# Altium schematic sheet rpi_adapter_2x4L_sink.SchDoc
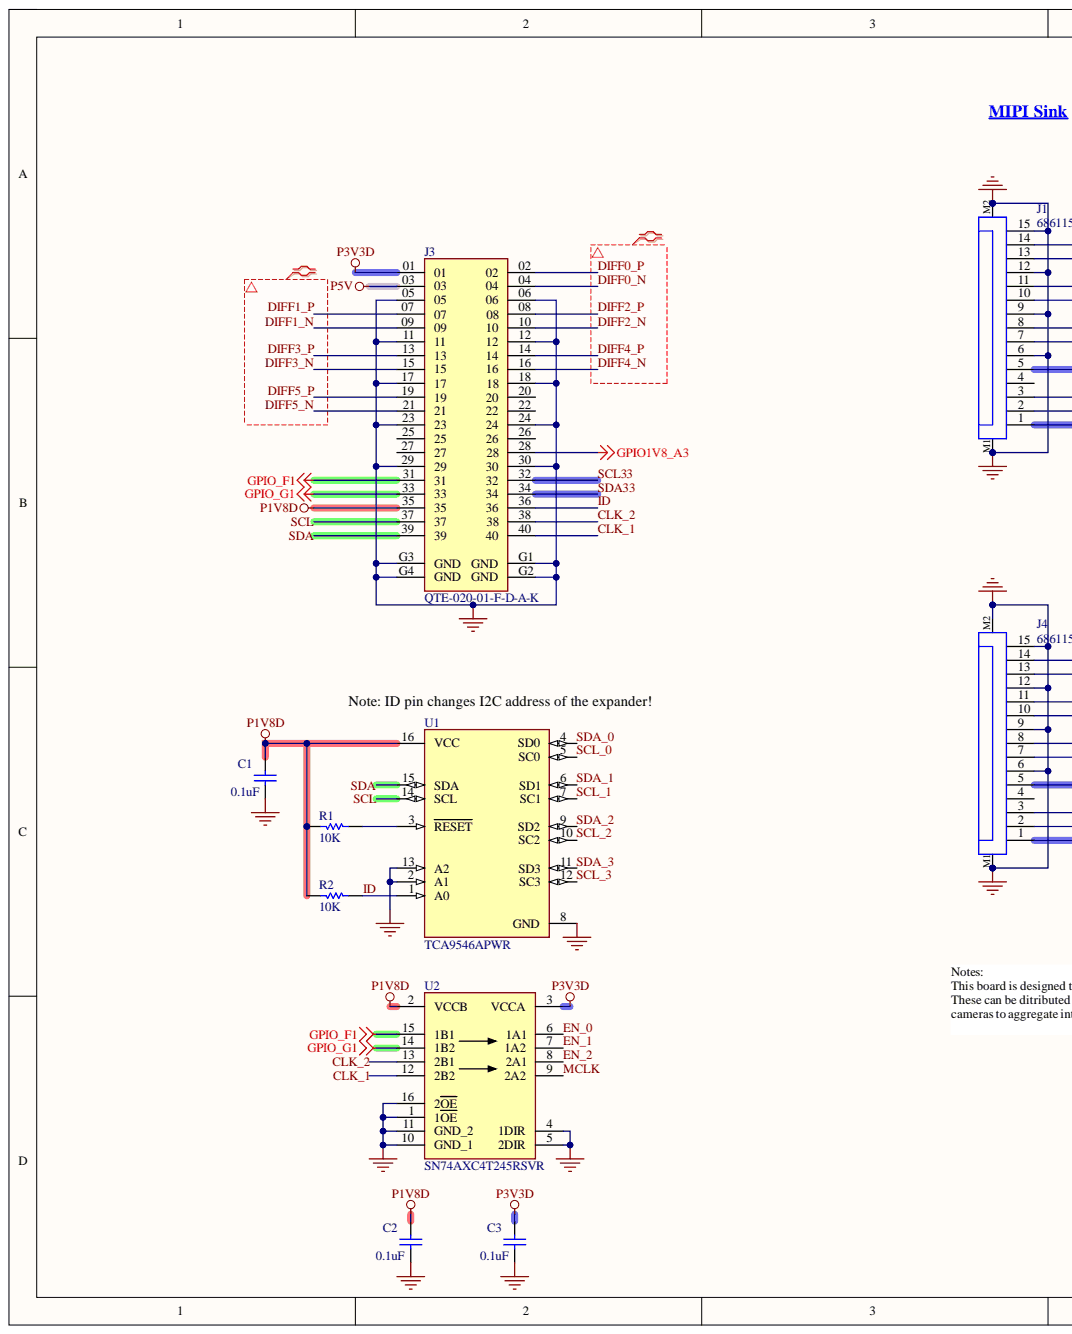
[diagram p0: rpi_adapter_2x4L_sink.SchDoc, left]
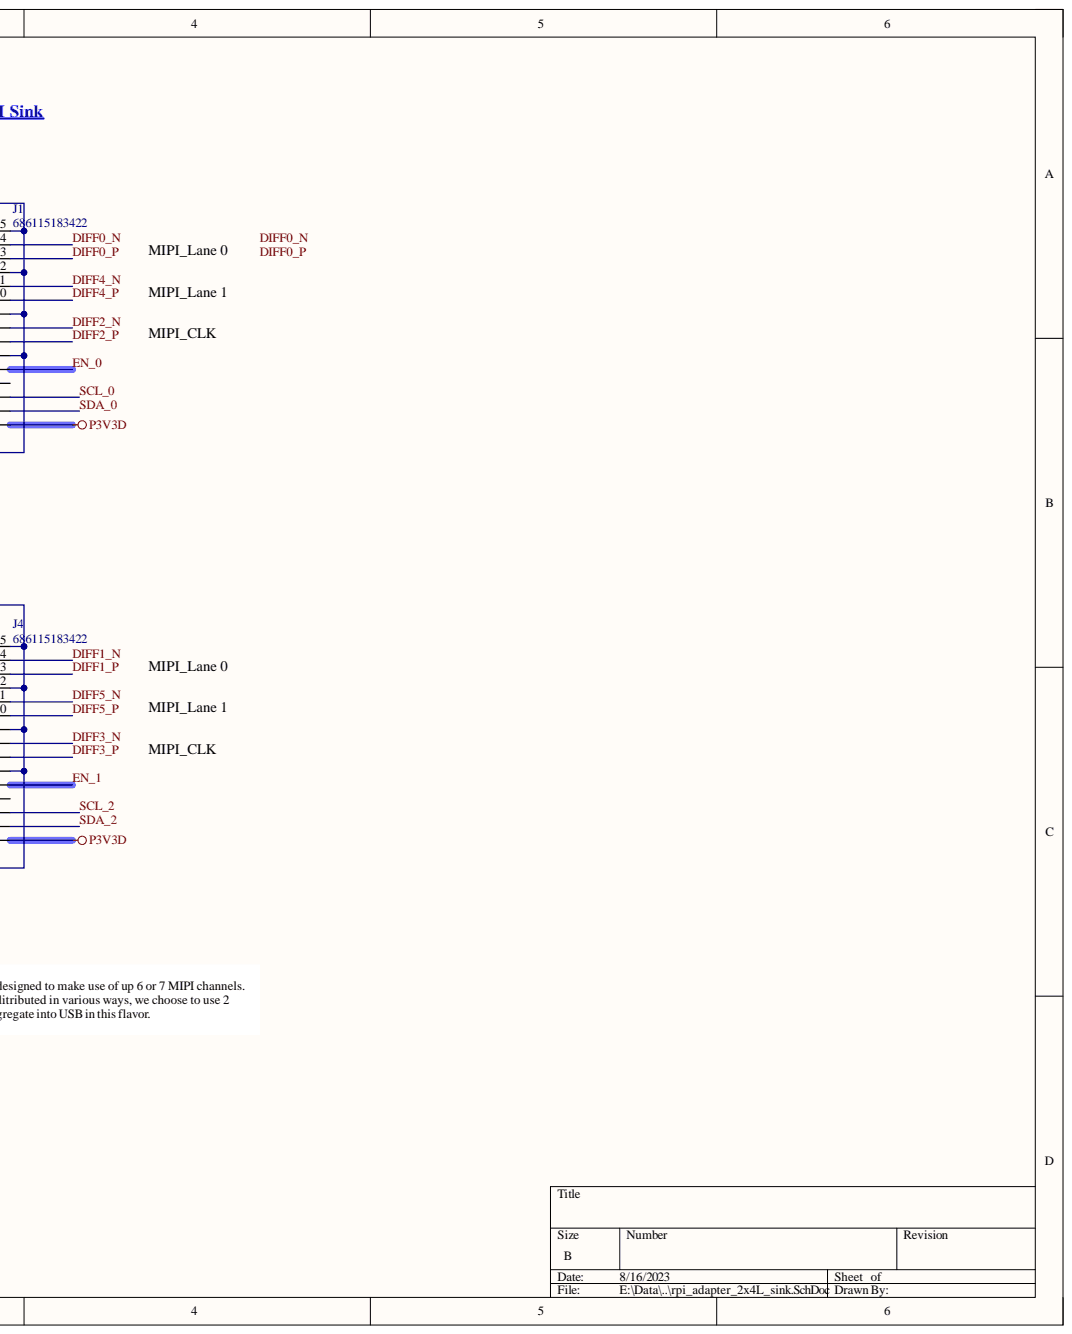
[diagram p1: rpi_adapter_2x4L_sink.SchDoc, right]

## Parts
C1  0.1uF  [CAPC1005X55USR]
C2  0.1uF  [CAPC1005X55USR]
C3  0.1uF  [CAPC1005X55USR]
J1  686115183422  [686115183422]
J3  QTE-020-01-F-D-A-K  [SAMTEC_QSE-020-01-XXX-D-A-L]
J4  686115183422  [686115183422]
R1  10K  [RESC1005X40USR]
R2  10K  [RESC1005X40USR]
U1  TCA9546APWR  [FP-PW0016A-MFG]
U2  SN74AXC4T245RSVR  [QFN40P260X180X55-16N]

## Nets
GND: C1.1 C2.1 C3.1 J1.6 J1.9 J1.12 J1.15 J1.M1 J1.M2 J3.05 J3.06 J3.11 J3.12 J3.17 J3.18 J3.23 J3.24 J3.29 J3.30 J3.G1(GND) J3.G2(GND) J3.G3(GND) J3.G4(GND) J4.6 J4.9 J4.12 J4.15 J4.M1 J4.M2 U1.2(A1) U1.8(GND) U1.13(A2) U2.1(1OE) U2.4(1DIR) U2.5(2DIR) U2.10(GND_1) U2.11(GND_2) U2.16(2OE)
GPIO1V8_A3: J3.28
GPIO_F1: J3.31 U2.15(1B1)
GPIO_G1: J3.33 U2.14(1B2)
P1V8D: C1.2 C2.2 J3.35 R1.1 R2.1 U1.16(VCC) U2.2(VCCB)
P3V3D: C3.2 J1.1 J3.01 J4.1 U2.3(VCCA)
P5V: J3.03
CLK_1: J3.40 U2.12(2B2)
CLK_2: J3.38 U2.13(2B1)
DIFF0_N: J1.14 J3.04
DIFF0_P: J1.13 J3.02
DIFF1_N: J3.09 J4.14
DIFF1_P: J3.07 J4.13
DIFF2_N: J1.8 J3.10
DIFF2_P: J1.7 J3.08
DIFF3_N: J3.15 J4.8
DIFF3_P: J3.13 J4.7
DIFF4_N: J1.11 J3.16
DIFF4_P: J1.10 J3.14
DIFF5_N: J3.21 J4.11
DIFF5_P: J3.19 J4.10
EN_0: J1.5 U2.6(1A1)
EN_1: J4.5 U2.7(1A2)
EN_2: U2.8(2A1)
ID: J3.36 R2.2 U1.1(A0)
MCLK: U2.9(2A2)
SCL: J3.37 U1.14(SCL)
SCL33: J3.32
SCL_0: J1.3 U1.5(SC0)
SCL_1: U1.7(SC1)
SCL_2: J4.3 U1.10(SC2)
SCL_3: U1.12(SC3)
SDA: J3.39 U1.15(SDA)
SDA33: J3.34
SDA_0: J1.2 U1.4(SD0)
SDA_1: U1.6(SD1)
SDA_2: J4.2 U1.9(SD2)
SDA_3: U1.11(SD3)
NetR1_2: R1.2 U1.3(RESET)

Not connected: J1 4; J3 20 22 25 26 27; J4 4

## Library data of the parts
C1-C3 = CAP CER 0.1UF 16V X7R 0402: Manufacturer: TDK Corporation; Manufacturer Part Number: CGA2B1X7R1C104K050BC; Component Kind: Standard; Description: CAP CER 0.1UF 16V X7R 0402; Height: 0.55mm; Library Name: NX Module baseboard.SCHLIB; Package: 0402; Supplier 1: Digi-Key; Supplier Part Number 1: 445-5613-2-ND; value: 0.1uF
J1 J4 = CMP-03913-81848314-1: 686115183422 WR-FPC 1.00mm SMT Horiz. Top Cont. Low Profile; Contact Resistance: 30mΩ; Current Rating: 800mA; Gender: Receptacle; Insulation Resistance: 100MΩ; Operating Supply Voltage: 50V; Pitch: 1mm; Component Kind: Standard; Lead Pitch: 1mm; Length: 16.1mm; Library Name: Altium Content Vault; Max Operating Temperature: 85°C; Min Operating Temperature: -25°C; NexarPartId: 81848314; Number of Pins: 15; Orientation: Horizontal; Packaging: Tape and Reel; RoHS: Compliant
J3 = QSE-020-01-F-D-A-K: Q Strip® High-Speed Ground Plane Header, 0.80mm Pitch; Manufacturer: Samtec; Gender: Male; Mated Stacking Heights: 5mm; Number of Contacts: 40; Pitch: 0.031inch; Category: Board to Board Connectors; Component Kind: Standard; ComponentLink1Description: https://datasheet.ciiva.com/6924/qte-xxx-xx-x-d-xxx-mkt-6924765.pdf?src-supplier=Digi-Key; ComponentLink1URL: https://datasheet.ciiva.com/6924/qte-xxx-xx-x-d-xxx-mkt-6924765.pdf?src-supplier=Digi-Key; Contact Plating: Gold; Library Name: NX Module baseboard.SchLib; Manufacturer Part Number: QTE-020-01-F-D-A; Mounting Technology: Surface Mount; Number of Positions: 40; Number of Rows: 2; Package Quantity: 1; Packaging: Tray; Pricing: 1=9.48000, 10=8.53000, 25=8.03560, 40=7.82950, 96=7.62344, 288=6.79931, 480=6.38725, 960=5.64550 (USD); RoHS: Yes; RoHS Compliant: Yes; Series: QStrip® QTE; Stock: 1329; Supplier 1: Digi-Key; Supplier Part Number 1: SAM8846-ND; Termination: Solder
R1 R2 = RES SMD 10K OHM 1% 1/16W 0402: Manufacturer: Samsung Electro-Mechanics; Manufacturer Part Number: RC1005F103CS; Component Kind: Standard; Description: RES SMD 10K OHM 1% 1/16W 0402; Height: 0.4mm; Library Name: NX Module baseboard.SCHLIB; Package: 0402; Supplier 1: Digi-Key; Supplier Part Number 1: 1276-3431-2-ND; value: 10K
U1 = CMP-04892-000001-1: Low Voltage 4-Channel I 2C and SMBus Switch with Reset Function; Manufacturer: Texas Instruments; Interface: I2C,SMBus; Max Supply Voltage: 5.5V; Min Supply Voltage: 1.65V; Nominal Supply Current: 2-50uA; Standoff Height: 0.05mm; Ambient Temperature Range High: +85°C; Ambient Temperature Range Low: -40°C; Automotive: No; Category: IC; Component Kind: Standard; ComponentLink2Description: Datasheet; ComponentLink2URL: http://www.ti.com/lit/ds/symlink/tca9546a.pdf; Device Class L1: Integrated Circuits (ICs); Device Class L2: Interface ICs; Device Class L3: Multiplexers; Digikey Description: IC I2C SW 4CH W/RESET 16TSSOP; Height: 1.2mm; Lead Free: Yes; Library Name: Altium Content Vault; Manufacturer Part Number: TCA9546APWR; Mouser Description: Switch ICs - Various 4-Channel I2C and SMBus; Octopart: https://octopart.com/tca9546apwr-texas+instruments-22292132; Package: PW0016A; RoHS: TRUE; Temperature: -40°C to +85°C; Temperature Range High: +85°C; Temperature Range Low: -40°C
U2 = SN74AXC4T245RSVR: 4-Bit Bus Transceiver with Configurable Voltage Translation and Tri-State Outputs 16-Pin UQFN; Manufacturer: Texas Instruments; Category: Buffers, Drivers and Transceivers; Component Kind: Standard; ComponentLink1Description: http://www.ti.com/general/docs/suppproductinfo.tsp?distId=10&amp;gotoUrl=http%3A%2F%2Fwww.ti.com%2Flit%2Fgpn%2Fsn74axc4t245&src-supplier=Digi-Key; ComponentLink1URL: http://www.ti.com/general/docs/suppproductinfo.tsp?distId=10&amp;gotoUrl=http%3A%2F%2Fwww.ti.com%2Flit%2Fgpn%2Fsn74axc4t245&src-supplier=Digi-Key; Library Name: NX Module baseboard.SCHLIB; Manufacturer Part Number: SN74AXC4T245RSVR; Pricing: 1=1.48000, 10=1.32500, 25=1.25760, 100=1.03290, 250=0.96552, 500=0.85326, 1000=0.67362 (USD); RoHS: Yes; Stock: 6479; Supplier 1: Digi-Key; Supplier Part Number 1: 296-53432-1-ND
